# Altium schematic sheet Irrigation Controller.SchDoc
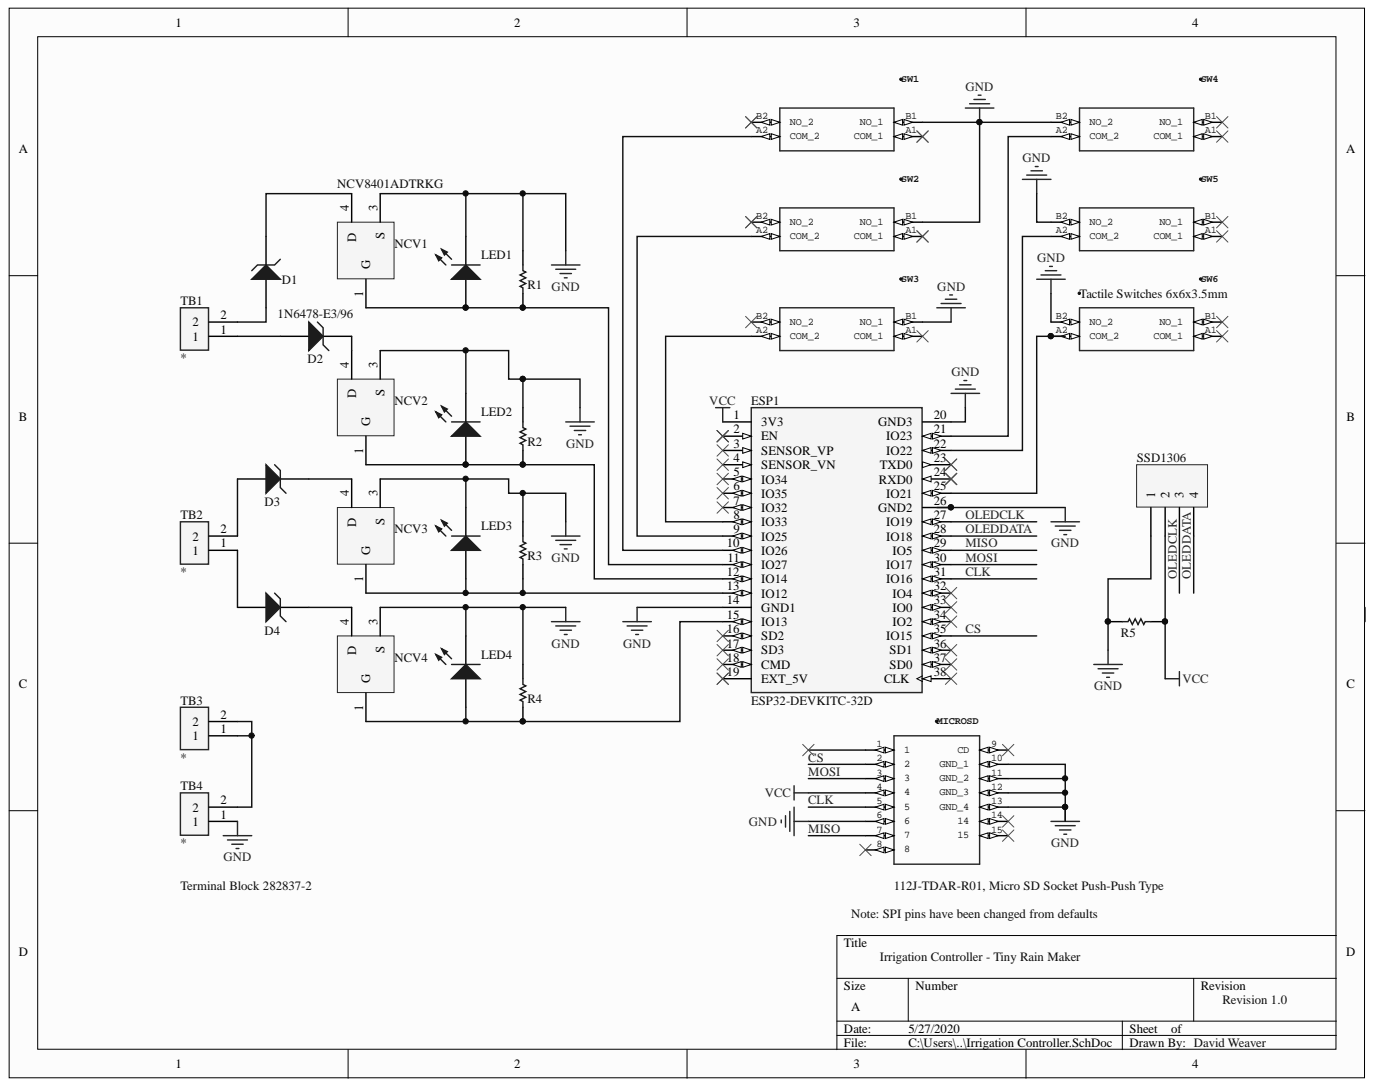
[diagram p0: Irrigation Controller.SchDoc]

## Parts
D1  1N6478-E3/96 - Rectifiers 1.0 Amp 50 Volt  [MCSM-DO-213AA-2_A_V]  (value not drawn)
D2  1N6478-E3/96  [MCSM-DO-213AA-2_A_V]
D3  JANTX1N754AUR-1  [MCSM-DO-213AA-2_A_V]  (value not drawn)
D4  JANTX1N754AUR-1  [MCSM-DO-213AA-2_A_V]  (value not drawn)
ESP1  ESP32-DEVKITC-32D  [MODULE_ESP32-DEVKITC-32D]
LED1  150120BS75000  [1206_A]  (value not drawn)
LED2  150120BS75000  [1206_A]  (value not drawn)
LED3  150120BS75000  [1206_A]  (value not drawn)
LED4  150120BS75000  [1206_A]  (value not drawn)
MICROSD  Micro SD Socket Push-Push Type, top mount   Source:  Datasheet  [112JTDARR]  (value not drawn)
NCV1  NCV8401ADTRKG  [ONSC-DPAK-3-369C-01_V]
NCV2  NCV8401ADTRKG  [ONSC-DPAK-3-369C-01_V]
NCV3  NCV8401ADTRKG  [ONSC-DPAK-3-369C-01_V]
NCV4  NCV8401ADTRKG  [ONSC-DPAK-3-369C-01_V]
R1  10K7 1% 1206(3216)  [RESC1206(3216)_N]  (value not drawn)
R2  10K7 1% 1206(3216)  [RESC1206(3216)_N]  (value not drawn)
R3  10K7 1% 1206(3216)  [RESC1206(3216)_N]  (value not drawn)
R4  10K7 1% 1206(3216)  [RESC1206(3216)_N]  (value not drawn)
R5  10K7 1% 1206(3216)  [RESC1206(3216)_N]  (value not drawn)
SSD1306  OLED  [HDR1X4]
SW1  Tactile Switches 6x6x3.5mm 3.5N 0.32mm Travel   Source:  Datasheet  [EVPBF6C1A000]  (value not drawn)
SW2  Tactile Switches 6x6x3.5mm 3.5N 0.32mm Travel   Source:  Datasheet  [EVPBF6C1A000]  (value not drawn)
SW3  Tactile Switches 6x6x3.5mm 3.5N 0.32mm Travel   Source:  Datasheet  [EVPBF6C1A000]  (value not drawn)
SW4  Tactile Switches 6x6x3.5mm 3.5N 0.32mm Travel   Source:  Datasheet  [EVPBF6C1A000]  (value not drawn)
SW5  Tactile Switches 6x6x3.5mm 3.5N 0.32mm Travel   Source:  Datasheet  [EVPBF6C1A000]
SW6  Tactile Switches 6x6x3.5mm  [EVPBF6C1A000]
TB1  Terminal Block 282837-2  [TE_282837-2]
TB2  282837-2  [TE_282837-2]  (value not drawn)
TB3  Terminal Block 282837-2  [TE_282837-2]
TB4  282837-2  [TE_282837-2]

## Nets
GND: ESP1.14(GND1) ESP1.20(GND3) ESP1.26(GND2) LED1.1 LED2.1 LED3.1 LED4.1 MICROSD.6 MICROSD.10(GND_1) MICROSD.11(GND_2) MICROSD.12(GND_3) MICROSD.13(GND_4) NCV1.3 NCV2.3 NCV3.3 NCV4.3 R1.2 R2.1 R3.2 R4.2 R5.2 SSD1306.1 SW1.B1(NO_1) SW2.B1(NO_1) SW3.B1(NO_1) SW4.B2(NO_2) SW5.B2(NO_2) SW6.B2(NO_2) TB4.1
VCC: ESP1.1(3V3) MICROSD.4 R5.1 SSD1306.2
CLK: ESP1.31(IO16) MICROSD.5
CS: ESP1.35(IO15) MICROSD.2
MISO: ESP1.29(IO5) MICROSD.7
MOSI: ESP1.30(IO17) MICROSD.3
OLEDCLK: ESP1.27(IO19) SSD1306.3
OLEDDATA: ESP1.28(IO18) SSD1306.4
NetD1_1: D1.1 TB1.2
NetD1_2: D1.2 NCV1.4
NetD2_1: D2.1 TB1.1
NetD2_2: D2.2 NCV2.4
NetD3_1: D3.1 TB2.2
NetD3_2: D3.2 NCV3.4
NetD4_1: D4.1 TB2.1
NetD4_2: D4.2 NCV4.4
NetESP1_8: ESP1.8(IO33) SW3.A2(COM_2)
NetESP1_9: ESP1.9(IO25) SW2.A2(COM_2)
NetESP1_10: ESP1.10(IO26) SW1.A2(COM_2)
NetESP1_11: ESP1.11(IO27) LED1.2 NCV1.1 R1.1
NetESP1_12: ESP1.12(IO14) LED2.2 NCV2.1 R2.2
NetESP1_13: ESP1.13(IO12) LED3.2 NCV3.1 R3.1
NetESP1_15: ESP1.15(IO13) LED4.2 NCV4.1 R4.1
NetESP1_21: ESP1.21(IO23) SW4.A2(COM_2)
NetESP1_22: ESP1.22(IO22) SW5.A2(COM_2)
NetESP1_25: ESP1.25(IO21) SW6.A2(COM_2)
NetTB3_1: TB3.1 TB3.2 TB4.2
N00006:

Not connected: ESP1 2(EN) 3(SENSOR_VP) 4(SENSOR_VN) 5(IO34) 6(IO35) 7(IO32) 16(SD2) 17(SD3) 18(CMD) 19(EXT_5V) 23(TXD0) 24(RXD0) 32(IO4) 33(IO0) 34(IO2) 36(SD1) 37(SD0) 38(CLK); MICROSD 1 8 9(CD) 14 15; SW1 A1(COM_1) B2(NO_2); SW2 A1(COM_1) B2(NO_2); SW3 A1(COM_1) B2(NO_2); SW4 A1(COM_1) B1(NO_1); SW5 A1(COM_1) B1(NO_1); SW6 A1(COM_1) B1(NO_1)

## Library data of the parts
D1 D3 D4 = CMP-2000-07615-1: Silicon MELF Zener Diode, 500 mW, 1.1 V, -65 to 175 degC, 2-Pin SMD (DO-213AA), Bulk; Manufacturer: Microsemi; Configuration: Single; Lead Finish: Tin/Lead; Maximum Power Dissipation: 480mW; Nominal Zener Voltage: 6.8V; Screening Level: Military; Type: VoltageRegulator; Component Kind: Standard; Datasheet URL: https://www.microsemi.com/document-portal/doc_download/131822-lds-0288-1; Datasheet Version: Rev. 1, 05/2013; Library Name: Altium Content Vault; Manufacturer URL: http://www.microsemi.com/; Mounting: SurfaceMount; Operating Temperature: -65to175C; Package Description: 2-Pin Metal Electrode Face Component, Body 3.505 x 1.65 mm; Package Reference: DO-213AA
D2 = CMP-2000-07615-1: Manufacturer: Microsemi; Configuration: Single; Lead Finish: Tin/Lead; Maximum Power Dissipation: 480mW; Nominal Zener Voltage: 6.8V; Screening Level: Military; Type: VoltageRegulator; Component Kind: Standard; Datasheet URL: https://www.microsemi.com/document-portal/doc_download/131822-lds-0288-1; Datasheet Version: Rev. 1, 05/2013; Description: 1N6478-E3/96; Library Name: Altium Content Vault; Manufacturer URL: http://www.microsemi.com/; Mounting: SurfaceMount; Operating Temperature: -65to175C; Package Description: 2-Pin Metal Electrode Face Component, Body 3.505 x 1.65 mm; Package Reference: DO-213AA
ESP1 = ESP32-DEVKITC-32D: - Transceiver; 802.11 b/g/n _Wi-Fi, WiFi, WLAN_, BluetoothÂ® Smart Ready 4.x Dual Mode For Use With ESP-WROOM-32; MF: Espressif Systems; Availability: Unavailable; Component Kind: Standard; Library Name: ESP32-DEVKITC-32D.IntLib; MP: ESP32-DEVKITC-32D; Package: None; Price: None; SnapEDA_Link: https://www.snapeda.com/parts/ESP32-DEVKITC-32D/Espressif%20Systems/view-part/2777395/?ref=snap
LED1-LED4 = CMP-1426-00015-1: SMD mono-color Chip LED, WL-SMCW, Blue; Manufacturer: Wuerth Elektronik; Color: Blue; Component Kind: Standard; ComponentLink1Description: Manufacturer URL; ComponentLink1URL: http://www.we-online.com; ComponentLink2Description: Datasheet; ComponentLink2URL: http://katalog.we-online.com/led/datasheet/150120BS75000.pdf; Library Name: Altium Content Vault; PackageReference: 1206_A; PartNumber: 150120BS75000
MICROSD = 112J-TDAR-R: Manufacturer_Name: ATTEND; GateName_1: G$1; Component Kind: Standard; DeviceSetName: 112J-TDAR-R; Height: 1mm; Library Name: 112J-TDAR-R.IntLib; LibraryName: 112J-TDAR-R; Manufacturer_Part_Number: 112J-TDAR-R; SymbolName_1: 112J-TDAR-R
NCV1-NCV4 = CMP-1069-00008-1: Self-Protected Low Side Driver with Temperature and Current Limit, 3-Pin DPAK, Pb-Free, Tape and Reel; Manufacturer: On Semiconductor; Channel Polarity: N Channel; V(BR)DSS Min (V): 42; Component Kind: Standard; ComponentLink1Description: Manufacturer URL; ComponentLink1URL: http://www.onsemi.com/; ComponentLink2Description: Datasheet; ComponentLink2URL: http://www.onsemi.com/pub_link/Collateral/NCV8401-D.PDF; ComponentLink3Description: Package Specification; ComponentLink3URL: http://www.onsemi.cn/pub_link/Collateral/369C.PDF; DatasheetVersion: Rev. 10; Library Name: Altium Content Vault; Mounting Technology: Surface Mount; PackageDescription: 3-Pin Flange Mount, Body 6.54 x 6.095 mm, Pitch 2.29 mm; PackageReference: DPAK-3-369C-01; PackageVersion: Rev. E, 02/2014; Packing: Tape and Reel; PartNumber: NCV8401ADTRKG; RoHS: Pb-Free
R1-R5 = CMP-1014-00626-1: 10K7 0.25W 1% 1206 (3216 Metric)  SMD; Case-EIA: 1206; Case-Metric: 3216; Value: 10700.00 Ohm; Component Kind: Standard; Library Name: Altium Content Vault; Power: 0.25 W; Technology: SMT; Tolerance: 1 %
SSD1306 = Header 4: Header, 4-Pin; Component Kind: Standard; LatestRevisionDate: 17-Jul-2002; LatestRevisionNote: Re-released for DXP Platform.; Library Name: Miscellaneous Connectors.IntLib; Publisher: Altium Limited
SW1-SW6 = EVP-BF6C1A000: Manufacturer_Name: Panasonic; GateName_1: G$1; Component Kind: Standard; DeviceSetName: EVP-BF6C1A000; Height: 3.7mm; Library Name: EVP-BF6C1A000.IntLib; LibraryName: EVP-BF6C1A000; Manufacturer_Part_Number: EVP-BF6C1A000; Mouser_Part_Number: 667-EVP-BF6C1A000; Mouser_Price-Stock: https://www.mouser.com/Search/Refine.aspx?Keyword=667-EVP-BF6C1A000; SymbolName_1: EVP-BF6C1A000
TB1-TB4 = 282837-2: Connector Terminal Blocks Receptacle 2 Position 5.08mm Solder Straight Thru-Hole 13.5A/Contact; MF: TE Connectivity; Component Kind: Standard; Digi-Key_Part_Number: A113320-ND; Digi-Key_Purchase_URL: https://www.digikey.in/product-detail/en/te-connectivity-amp-connectors/282837-2/A113320-ND/2187973?utm_source=snapeda&utm_medium=aggregator&utm_campaign=symbol; Library Name: 282837-2.IntLib; MP: 282837-2; Package: None; SnapEDA_Link: https://www.snapeda.com/parts/282837-2/TE%20Connectivity%20AMP%20Connectors/view-part/2549980/?ref=snap; TE_purchase_URL: https://www.te.com/usa-en/product-282837-2.html?te_bu=Cor&te_type=disp&te_campaign=seda_glo_cor-seda-global-disp-prtnr-fy19-seda-model-bom-cta_sma-317_1&elqCampaignId=32493
